# Altium schematic sheet Teensy.SchDoc(Teensy)
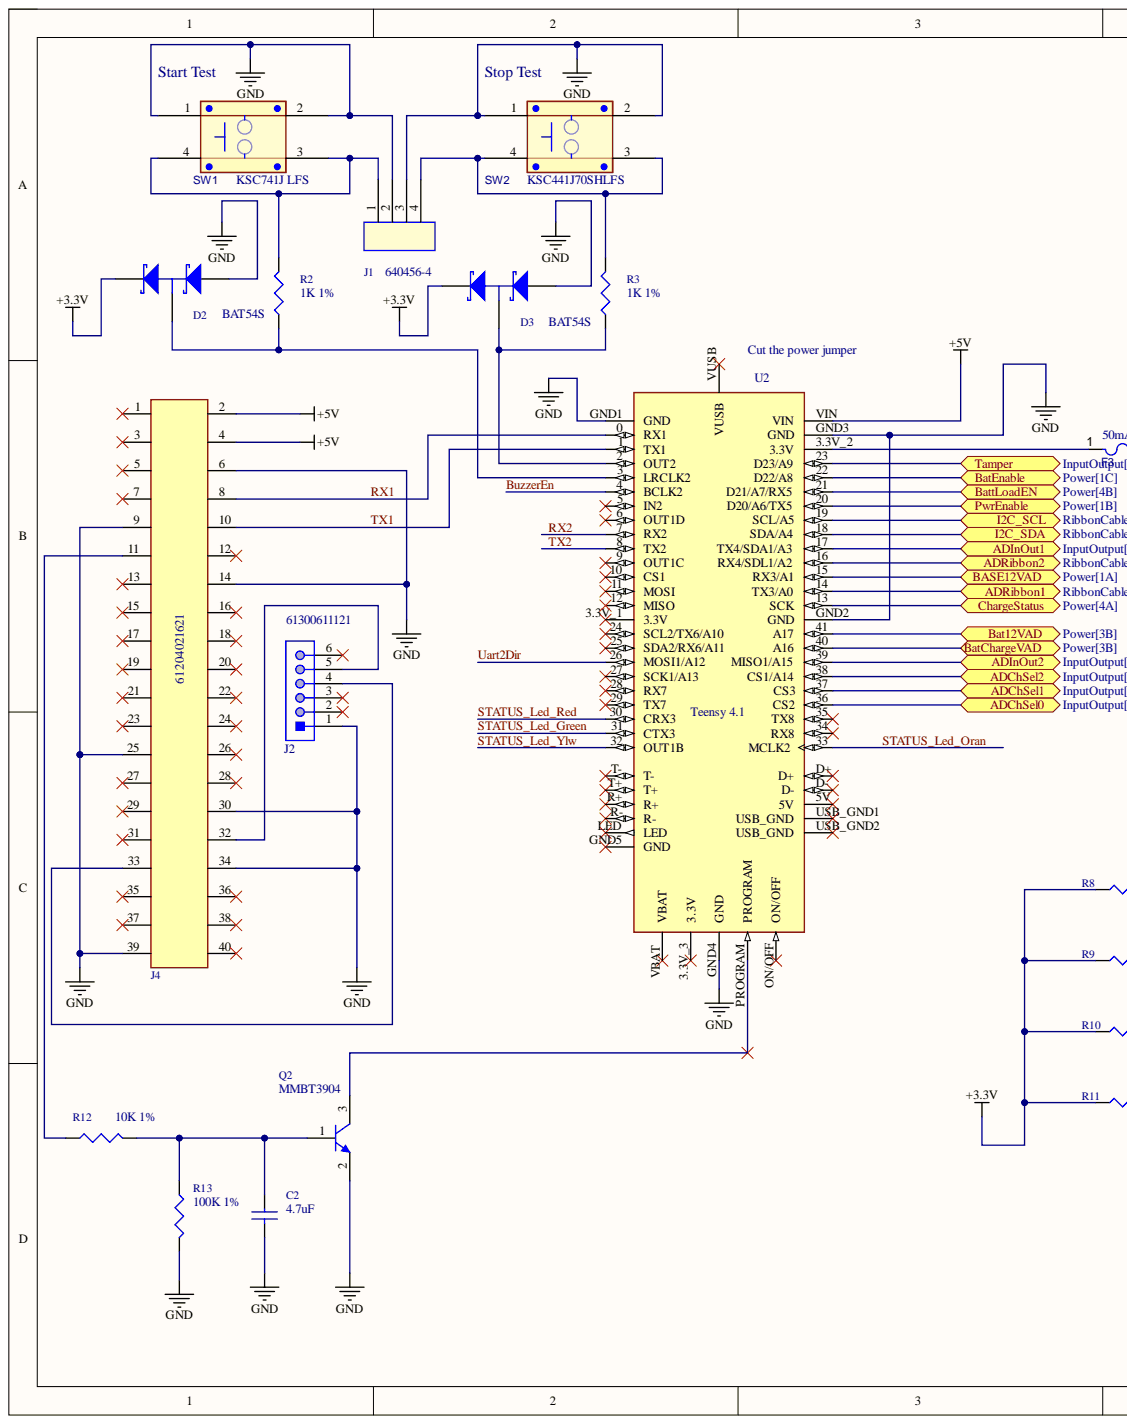
[diagram p0: Teensy.SchDoc, left]
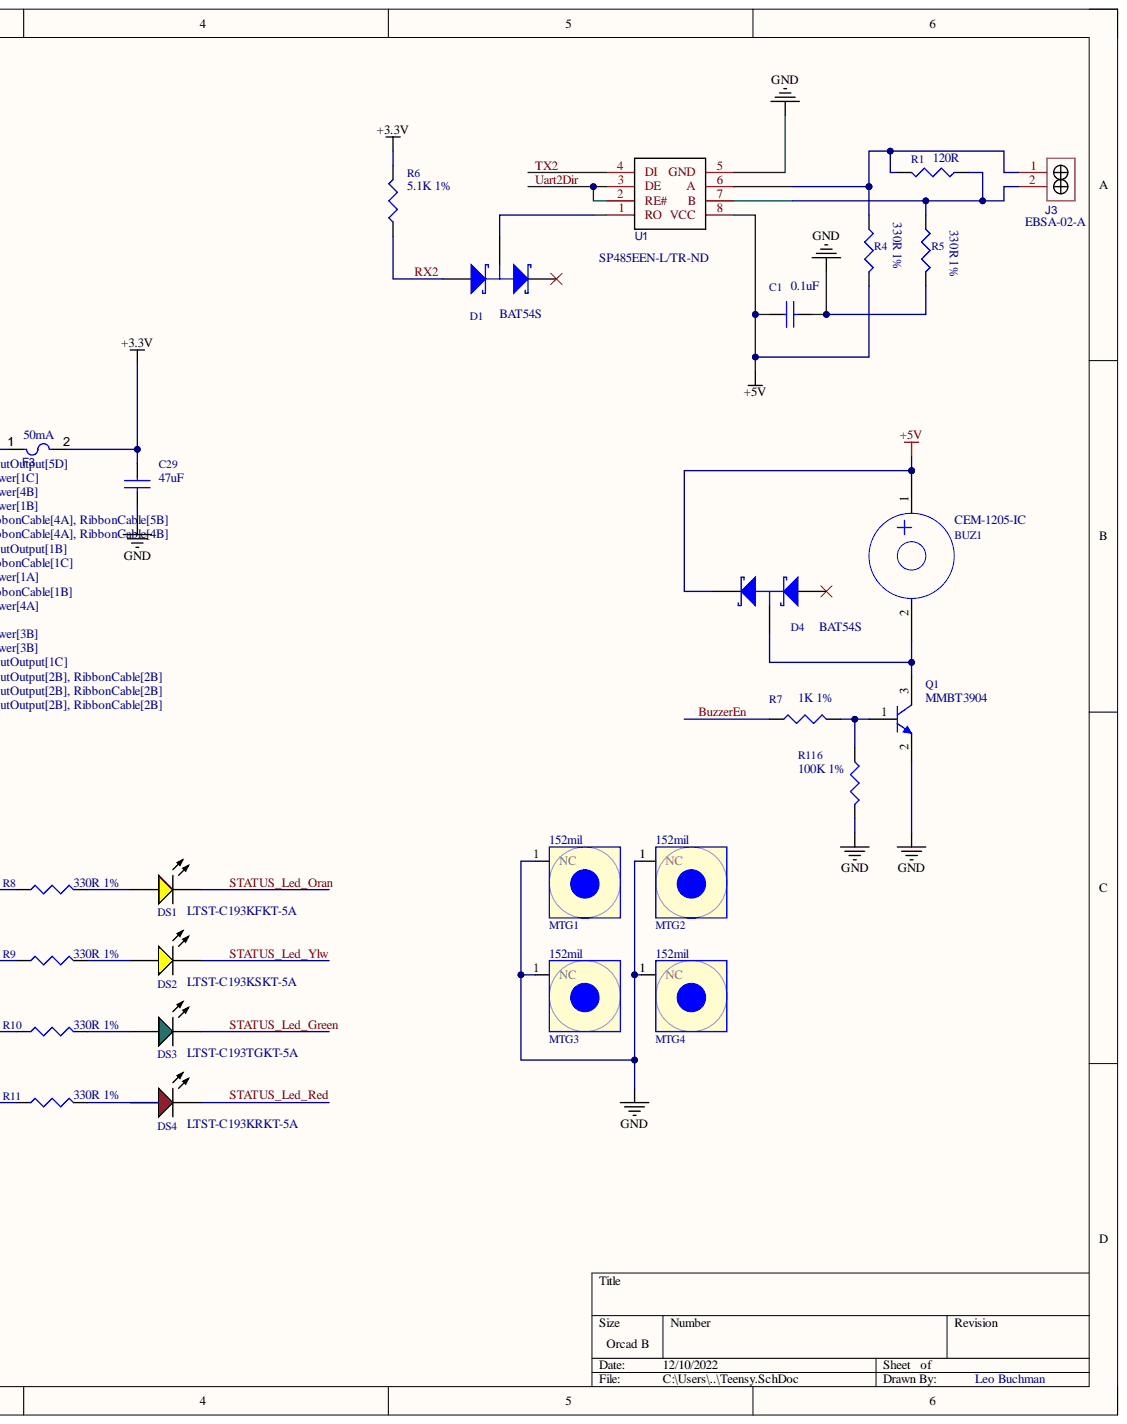
[diagram p1: Teensy.SchDoc, right]

## Parts
BUZ1  CEM-1205-IC  [BUZZ-MB5120C]
C1  0.1uF  [C0402]
C2  4.7uF  [C0603]
C29  47uF  [C1210]
D1  BAT54S  [sot23-3]
D2  BAT54S  [sot23-3]
D3  BAT54S  [sot23-3]
D4  BAT54S  [sot23-3]
DS1  LTST-C193KFKT-5A  [LED-ORANGE_0603]
DS2  LTST-C193KSKT-5A  [LED-YELLOW_0603]
DS3  LTST-C193TGKT-5A  [LED-GREEN_0603]
DS4  LTST-C193KRKT-5A  [LED-RED_0603]
F3  50mA  [F0603]
J1  640456-4  [CON$5C$4P$5C$100L]
J2  61300611121  [61300611121]
J3  EBSA-02-A  [CONN-TH_2P-P3.50_JL15EDGRC-35002G01]
J4  61204021621  [IDC-TH_Z-231011040106]
MTG1  152mil  [MNT-HOLE-169-350]  (no BOM)
MTG2  152mil  [MNT-HOLE-169-350]  (no BOM)
MTG3  152mil  [MNT-HOLE-169-350]  (no BOM)
MTG4  152mil  [MNT-HOLE-169-350]  (no BOM)
Q1  MMBT3904  [sot23-3]
Q2  MMBT3904  [sot23-3]
R1  120R  [R0402]
R2  1K 1%  [R0402]
R3  1K 1%  [R0402]
R4  330R 1%  Resistance: 330R  Tolerance: 1%  [R0402]
R5  330R 1%  Resistance: 330R  Tolerance: 1%  [R0402]
R6  5.1K 1%  [R0402]
R7  1K 1%  Tolerance: 1%  [R0402]
R8  330R 1%  Resistance: 330R  [R0402]
R9  330R 1%  Resistance: 330R  [R0402]
R10  330R 1%  Resistance: 330R  [R0402]
R11  330R 1%  Resistance: 330R  [R0402]
R12  10K 1%  Tolerance: 1%  [R0402]
R13  100K 1%  [R0402]
R116  100K 1%  [R0402]
SW1  KSC741J LFS  [SON4_KSC741JLFS_CNK-blue]
SW2  KSC441J70SHLFS  [SON4_KSC741JLFS_CNK-red]
U1  SP485EEN-L/TR-ND  [NSOIC-8]
U2  Teensy 4.1  [MODULE_DEV-16771 - headers]

## Ports
ADChSel0, ADChSel1, ADChSel2, ADInOut1, ADInOut2, ADRibbon1, ADRibbon2, BASE12VAD, Bat12VAD, BatChargeVAD, BatEnable, BattLoadEN, ChargeStatus, I2C_SCL, I2C_SDA, PwrEnable, Tamper

## Nets
+3.3V: C29.1 D2.2 D3.2 F3.2 R6.2 R8.1 R9.1 R10.1 R11.1
+5V: BUZ1.1 C1.1 D4.2 J4.2 J4.4 R4.2 U1.8(VCC) U2.VIN
GND: C1.2 C2.2 C29.2 D2.1 D3.1 J1.2 J1.3 J2.1 J4.6 J4.9 J4.14 J4.25 J4.30 J4.34 J4.39 MTG1.1 MTG2.1 MTG3.1 MTG4.1 Q1.2 Q2.2 R5.2 R13.2 R116.2 SW1.1 SW1.2 SW2.1 SW2.2 U1.5(GND) U2.GND1(GND) U2.GND2(GND) U2.GND3(GND) U2.GND4(GND)
ADChSel0: U2.36(CS2) ; port ADChSel0
ADChSel1: U2.37(CS3) ; port ADChSel1
ADChSel2: U2.38(CS1/A14) ; port ADChSel2
ADInOut1: U2.17(TX4/SDA1/A3) ; port ADInOut1
ADInOut2: U2.39(MISO1/A15) ; port ADInOut2
ADRibbon1: U2.14(TX3/A0) ; port ADRibbon1
ADRibbon2: U2.16(RX4/SDL1/A2) ; port ADRibbon2
BASE12VAD: U2.15(RX3/A1) ; port BASE12VAD
Bat12VAD: U2.41(A17) ; port Bat12VAD
BatChargeVAD: U2.40(A16) ; port BatChargeVAD
BatEnable: U2.22(D22/A8) ; port BatEnable
BattLoadEN: U2.21(D21/A7/RX5) ; port BattLoadEN
BuzzerEn: R7.1 U2.4(BCLK2)
ChargeStatus: U2.13(SCK) ; port ChargeStatus
I2C_SCL: U2.19(SCL/A5) ; port I2C_SCL
I2C_SDA: U2.18(SDA/A4) ; port I2C_SDA
PwrEnable: U2.20(D20/A6/TX5) ; port PwrEnable
RX1: J4.8 U2.0(RX1)
RX2: D1.1 R6.1 U2.7(RX2)
STATUS_Led_Green: DS3.2 U2.31(CTX3)
STATUS_Led_Oran: DS1.2 U2.33(MCLK2)
STATUS_Led_Red: DS4.2 U2.30(CRX3)
STATUS_Led_Ylw: DS2.2 U2.32(OUT1B)
Tamper: U2.23(D23/A9) ; port Tamper
TX1: J4.10 U2.1(TX1)
TX2: U1.4(DI) U2.8(TX2)
Uart2Dir: U1.2(RE#) U1.3(DE) U2.26(MOSI1/A12)
NetBUZ1_2: BUZ1.2 D4.3 Q1.3
NetC2_1: C2.1 Q2.1 R12.2 R13.1
NetD1_3: D1.3 U1.1(RO)
NetD2_3: D2.3 R2.1 U2.3(LRCLK2)
NetD3_3: D3.3 R3.1 U2.2(OUT2)
NetDS1_1: DS1.1 R8.2
NetDS2_1: DS2.1 R9.2
NetDS3_1: DS3.1 R10.2
NetDS4_1: DS4.1 R11.2
NetF3_1: F3.1 U2.3.3V_2(3.3V)
NetJ1_1: J1.1 R2.2 SW1.3 SW1.4
NetJ1_4: J1.4 R3.2 SW2.3 SW2.4
NetJ2_4: J2.4 J4.33
NetJ2_5: J2.5 J4.32
NetJ3_1: J3.1 R1.2 R4.1 U1.6(A)
NetJ3_2: J3.2 R1.1 R5.1 U1.7(B)
NetJ4_11: J4.11 R12.1
NetQ1_1: Q1.1 R7.2 R116.1
NetQ2_3: Q2.3 U2.PROGRAM

Not connected: D1 2; D4 1; J2 2 3 6; J4 1 3 5 7 12 13 15 16 17 18 19 20 21 22 23 24 26 27 28 29 31 35 36 37 38 40; U2 3.3V_1(3.3V) 3.3V_3(3.3V) 5(IN2) 5V 6(OUT1D) 9(OUT1C) 10(CS1) 11(MOSI) 12(MISO) 24(SCL2/TX6/A10) 25(SDA2/RX6/A11) 27(SCK1/A13) 28(RX7) 29(TX7) 34(RX8) 35(TX8) D+ D- GND5(GND) LED ON/OFF R+ R- T+ T- USB_GND1(USB_GND) USB_GND2(USB_GND) VBAT VUSB

## Library data of the parts
BUZ1 = Mod-0003: 12 mm, 5 Vdc, 92 dB, Through Hole, Driving Circuit, Magnetic Audio Indicator Buzzer; ADI Part Number: 3716-0001; Manufacturer: CUI Devices; CLASS: DISCRETE; COMPFIXED: NO; COMPGROUP: 0; COMPHEIGHT: 0.000; COMPLOC: [1301.000,1659.000]; COMPROT: 180; COMPSIDE: TOP; Current: 35mA; Database Table Name: Modules; S2 PN: S2-BZ-0001; Voltage: 5V; Category: Buzzers; COMPKEY: NO; COMPLOCKED: NO; Component Kind: Standard; DECOUPLER: NO; JLCPCB_PART: NA; LCSC Part Number: N/A; Manufacturer Part Number: CEM-1205-IC; Mount: Through Hole; Part Name: Mod-0003; PCB Footprint: BUZZ-MB5120C; Pricing 1: 1=1.87000, 10=1.55000, 50=1.35000, 100=1.2700; RoHS: Yes; S2PN: S2-BZ-0001; Stock 1: 774; Supplier 1: Mouser; Supplier Part Number 1: 490-CEM1205IC; Library Name: Leo-Home-SQL-Lib.DbLib
C1 = Cap-00005: Cap Ceramic 0.1uF 50V X7R 10% Pad SMD 0402 125C Automotive T/R; Manufacturer: Murata; Manufacturer Part Number: GRT155R71H104KE01D; Database Table Name: Capacitors; Case Code (Imperial): 0402; Case Code (Metric): 1005; Case/Package: 0402; Category: Ceramic Capacitors; Component Kind: Standard; Dielectric Material: Ceramic; LCSC Part Number: C307331; Mount: Surface Mount; Number of Pins: 2; Part Name: Cap-00005; Pricing 1: 1=0.10000, 10=0.04700, 50=0.02580, 100=0.0211; RoHS: Yes; RoHS Compliant: Yes; Stock 1: 179948; Supplier 1: Digi-Key; Supplier Part Number 1: 490-GRT155R71H104KE01DCT-ND; Voltage Rating (DC): 50V; Library Name: Leo-Home-SQL-Lib.DbLib
C2 = Cap-00001: CAP CER 4.7UF 16V X5R 0603; Manufacturer: Samsung; Manufacturer Part Number: CL10A475KO8NNNC; Database Table Name: Capacitors; Dielectric Material: Ceramic; Tolerance: 10%; Capacitance: 4.7uF; Case Code (Imperial): 0603; Case Code (Metric): 1608; Case/Package: 0603; Category: Ceramic Capacitors; Component Kind: Standard; ComponentLink1Description: https://datasheet.ciiva.com/25795/cl10a475ko8; ComponentLink1URL: https://datasheet.ciiva.com/25795/cl10a475ko8; ComponentLink2Description: https://datasheet.ciiva.com/26838/getdatashee; ComponentLink2URL: https://datasheet.ciiva.com/26838/getdatashee; ComponentLink3Description: https://datasheet.ciiva.com/29198/457663-da-0; ComponentLink3URL: https://datasheet.ciiva.com/29198/457663-da-0; Construction: Flat; Contact Plating: Tin; Depth: 0.8mm; Dielectric: X5R; Height: 0.8mm; LCSC Part Number: C19666; Length: 1.6mm; Material: Ceramic; Max Operating Temperature: 85°C; Min Operating Temperature: -55°C; Mount: Surface Mount; Number of Pins: 2; Package Quantity: 1; Packaging: Tape and Reel; Part Name: Cap-00001; Pricing 1: 1=0.13000, 10=0.09100, 50=0.05180, 100=0.0429; Radiation Hardening: No; RoHS: Yes; RoHS Compliant: Yes; Series: CL; Stock 1: 1593998; Supplier 1: Digi-Key; Supplier Part Number 1: 1276-1784-1-ND; Thickness: 0.035inch; Voltage: 16V; Voltage Rating: 16V; Width: 0.031inch; Library Name: Leo-Home-SQL-Lib.DbLib
C29 = Cap-00004: CAP CER 47UF 16V X5R 1210; Manufacturer: Murata; Manufacturer Part Number: GRM32ER61C476ME15L; Database Table Name: Capacitors; Dielectric Material: Ceramic; Tolerance: 20%; Capacitance: 47uF; Case Code (Imperial): 1210; Case Code (Metric): 3225; Case/Package: 1210; Category: Ceramic Capacitors; Component Kind: Standard; ComponentLink1Description: https://datasheet.ciiva.com/141/product-14197; ComponentLink1URL: https://datasheet.ciiva.com/141/product-14197; ComponentLink2Description: https://datasheet.ciiva.com/14116/grm32er61c4; ComponentLink2URL: https://datasheet.ciiva.com/14116/grm32er61c4; ComponentLink3Description: https://datasheet.ciiva.com/7719/415303-77191; ComponentLink3URL: https://datasheet.ciiva.com/7719/415303-77191; ComponentLink4Description: https://datasheet.ciiva.com/141/partnumbering; ComponentLink4URL: https://datasheet.ciiva.com/141/partnumbering; ComponentLink5Description: https://datasheet.ciiva.com/141/partnumbering; ComponentLink5URL: https://datasheet.ciiva.com/141/partnumbering; ComponentLink6Description: https://datasheet.ciiva.com/16343/c02e-163436; ComponentLink6URL: https://datasheet.ciiva.com/16343/c02e-163436; Depth: 2.5mm; Dielectric: X5R; Height: 2.5mm; LCSC Part Number: C77101; Lead Free: Lead Free; Length: 3.2mm; Max Operating Temperature: 85°C; Min Operating Temperature: -55°C; Mount: Surface Mount; Number of Pins: 2; Package Quantity: 1000; Packaging: Tape and Reel; Part Name: Cap-00004; Pricing 1: 1=0.73000, 10=0.53900, 50=0.43120, 100=0.3697; REACH SVHC: No SVHC; RoHS: Yes; RoHS Compliant: Yes; Series: GRM; Stock 1: 238447; Supplier 1: Digi-Key; Supplier Part Number 1: 490-3888-1-ND; Termination: SMD/SMT; Thickness: 0.106inch; Voltage: 16V; Voltage Rating: 16V; Voltage Rating (DC): 16V; Width: 0.098inch; Library Name: Leo-Home-SQL-Lib.DbLib
D1-D4 = Diode-0009: DIODE SCHOTTKY 30V 150MA SOT23-3; Manufacturer: Sangdest Microelectronics; Database Table Name: Diodes; Elements: 2; Package Version: Rev. 12, 03/2016; Peak Reverse Current: 2uA; Recovery Time: 5ns; Component Kind: Standard; Component Type: Diodes; Datasheet URL: https://www.fairchildsemi.com/datasheets/BA/BAT54S.pdf; Datasheet Version: Rev. 2.3, 04/2016; LCSC Part Number: C47546; Lead Free: Lead Free; Manufacturer Part Number: BAT54S; Manufacturer URL: https://www.fairchildsemi.com/; Mount: Surface Mount; Mounting Technology: SM; Package Description: 3-Pin Small Outline Transistor, Body 2.92 x 1.325 mm, Pitch 0.95 mm; Package Reference: SOT23-3; Package URL: http://www.fairchildsemi.com/dwg/MA/MA03D.pdf; Part Name: Diode-0009; Pins: 3; Pricing 1: 1=0.43000, 10=0.35100, 100=0.18620, 500=0.122; Radiation Hardening: No; RoHS: Yes; Stock 1: 168252; Supplier 1: Digi-Key; Supplier Part Number 1: 1655-BAT54SCT-ND; Library Name: Leo-Home-SQL-Lib.DbLib
DS1 = Diode-0004: LED Orange CLEAR 0603 SMD; Manufacturer: Vishay Lite-On; Database Table Name: Diodes; Dominant Wavelength: 605nm; Forward (Drive) Current: 20mA; Forward Current: 5mA; Forward Voltage: 2.0V; Intensity: 22mcd; Lens Color: Colorless; Lens Style: Diffused,Colorless,Rectangular,Clear; Luminous Intensity: 28.1mcd; Number of Elements: 1; Viewing Angle: 130°; Case Code (Imperial): 0603; Case Code (Metric): 1608; Case/Package: 0603; Category: LEDs; Color: Orange; Component Kind: Standard; Datasheet URL: https://datasheet.ciiva.com/11773/0900766b80df3ad8-11773825.pdf; Datasheet Version: Rev. 2.0, 03/2010; Dimension: 1.6x0.8x0.36mm; Height: 0.35mm; Illumination Color: Orange; LCSC Part Number: C434425; Lead Free: Lead Free; Lens Transparency: Clear; Lens Type: Clear; Manufacturer Part Number: LTST-C193KFKT-5A; Manufacturer URL: http://www.rohm.com/web/global/; Max Operating Temperature: 85°C; Min Operating Temperature: -55°C; Mount: Surface Mount; Mounting Technology: SurfaceMount; Number of Pins: 2; Package Description: 2-Pin Surface Mount Device, Body 1.6 x 0.8 mm; Package Reference: 1608; Packaging: Tape and Reel; Part Name: Diode-0004; Pricing 1: 1=0.39000, 10=0.20900, 100=0.09720, 500=0.089; Radiation Hardening: No; RoHS: Yes; RoHS Compliant: Yes; Stock 1: 55639; Supplier 1: Digi-Key; Supplier Part Number 1: 160-1829-1-ND; Width: 0.8mm; Library Name: Leo-Home-SQL-Lib.DbLib
DS2 = Diode-0006: LED Yellow CLEAR 0603 SMD; Manufacturer: Vishay Lite-On; Case\Package: 0603; Database Table Name: Diodes; Dominant Wavelength: 594.5nm; Forward Current: 30mA; Forward Voltage: 2.0V; Lens Color: Colorless; Lens Style: Diffused,Colorless,Rectangular; Luminous Intensity: 45mcd; Number of Elements: 1; Power Dissipation: 75mW; Resistance: 390 Ohm; Reverse Voltage: 5V; Test Current: 5mA; Viewing Angle: 130°; Voltage Rating: 50 V; Case Code (Imperial): 0603; Case Code (Metric): 1608; Case/Package: 0603; Category: LEDs; Color: Yellow; Component Kind: Standard; ComponentLink1Description: https://datasheet.ciiva.com/11775/0900766b80d; ComponentLink1URL: https://datasheet.ciiva.com/11775/0900766b80d; ComponentLink2Description: https://datasheet.ciiva.com/4688/lite-on-ltst; ComponentLink2URL: https://datasheet.ciiva.com/4688/lite-on-ltst; ComponentLink3Description: https://datasheet.ciiva.com/26890/getdatashee; ComponentLink3URL: https://datasheet.ciiva.com/26890/getdatashee; ComponentLink4Description: https://datasheet.ciiva.com/2110/s-110-ltst-c; ComponentLink4URL: https://datasheet.ciiva.com/2110/s-110-ltst-c; ComponentLink5Description: https://datasheet.ciiva.com/2110/s-110-ltst-c; ComponentLink5URL: https://datasheet.ciiva.com/2110/s-110-ltst-c; ComponentLink6Description: https://datasheet.ciiva.com/2110/s-110-ltst-c; ComponentLink6URL: https://datasheet.ciiva.com/2110/s-110-ltst-c; ComponentLink7Description: https://datasheet.ciiva.com/2110/ltst-c193kfk; ComponentLink7URL: https://datasheet.ciiva.com/2110/ltst-c193kfk; DatasheetVersion: Mar-12; Depth: 0.8mm; Height: 0.35mm; Illumination Color: Yellow; LCSC Part Number: C72038; Lead Free: Lead Free; Length: 1.6mm; Lens Transparency: Clear; Manufacturer Part Number: LTST-C193KSKT-5A; Max Operating Temperature: 85°C; Min Operating Temperature: -55°C; Mount: Surface Mount; Number of LEDs: 1; Number of Pins: 2; Package Quantity: 1; PackageDescription: 2-Pin Surface Mount Device, Body 1.6 x 0.8 mm, Height 0.9 mm; PackageReference: HSMX-C190; Packaging: Tape and Reel; Part Name: Diode-0006; Pins: 2; Power Rating: 75mW; Pricing 1: 1=0.39000, 10=0.20900, 100=0.09720, 500=0.089; Radiation Hardening: No; RoHS: Yes; RoHS Compliant: Yes; RoHSCompliant: true; Stock 1: 147319; Supplier 1: Digi-Key; Supplier Part Number 1: 160-1831-1-ND; Width: 0.8mm; Library Name: Leo-Home-SQL-Lib.DbLib
DS3 = Diode-0007: LED Green CLEAR 0603 SMD; Manufacturer: Vishay Lite-On; Access Time: 3500 ns; Average Rectified Current: 2A; Case\Package: SOIC; Clock Frequency: 100 KHz; Database Table Name: Diodes; Density: 32 kb; Dominant Wavelength: 535nm; Element Configuration: Single; Forward Current: 5mA; Forward Voltage: 2.0V; Frequency: 400 KHz; Lens Color: Colorless; Lens Style: Diffused,Colorless,Rectangular; Luminous Intensity: 280mcd; Max Repetitive Reverse Voltage (Vrrm): 40V; Max Reverse Current: 500uA; Max Supply Voltage: 5.5 V; Min Supply Voltage: 1.7 V; Nominal Supply Current: 2 mA; Number of Elements: 1; Peak Non-Repetitive Surge Current: 50A; Power Dissipation: 76mW; Reverse Voltage: 5V; Viewing Angle: 130°; Case Code (Imperial): 0603; Case Code (Metric): 1608; Case/Package: 0603; Category: LEDs; Color: Green; Component Kind: Standard; ComponentLink1Description: https://datasheet.ciiva.com/2111/ltst-c193tgk; ComponentLink1URL: https://datasheet.ciiva.com/2111/ltst-c193tgk; ComponentLink2Description: https://datasheet.ciiva.com/11775/0900766b80d; ComponentLink2URL: https://datasheet.ciiva.com/11775/0900766b80d; ComponentLink3Description: https://datasheet.ciiva.com/4689/lite-on-ltst; ComponentLink3URL: https://datasheet.ciiva.com/4689/lite-on-ltst; ComponentLink4Description: https://datasheet.ciiva.com/2111/p-100-ltst-c; ComponentLink4URL: https://datasheet.ciiva.com/2111/p-100-ltst-c; ComponentLink5Description: https://datasheet.ciiva.com/2111/p-100-ltst-c; ComponentLink5URL: https://datasheet.ciiva.com/2111/p-100-ltst-c; ComponentLink6Description: http://www.mouser.com/ds/2/115/B220AQ_Q-B260A; ComponentLink6URL: http://www.mouser.com/ds/2/115/B220AQ_Q-B260A; ComponentLink7Description: https://www.diodes.com/assets/Datasheets/ds13; ComponentLink7URL: https://www.diodes.com/assets/Datasheets/ds13; ComponentLink8Description: http://www.diodes.com/datasheets/ds13004.pdf?; ComponentLink8URL: http://www.diodes.com/datasheets/ds13004.pdf?; Contact Plating: Tin,Matte; DatasheetVersion: Mar-12; Depth: 0.8mm; Height: 0.35mm; Illumination Color: Green; LCSC Part Number: C72043; Lead Free: Lead Free; Length: 1.6mm; Lens Transparency: Clear; Manufacturer Part Number: LTST-C193TGKT-5A; Max Clock Frequency: 100 KHz; Max Operating Temperature: 80°C; Max Reverse Voltage (DC): 40V; Min Operating Temperature: -20°C; Mount: Surface Mount; Number of LEDs: 1; Number of Pins: 2; Package Quantity: 3000; PackageDescription: 2-Pin Surface Mount Device, Body 1.6 x 0.8 mm, Height 0.9 mm; PackageReference: HSMX-C190; Packaging: Tape and Reel; Part Name: Diode-0007; Pins: 8; Pricing 1: 1=0.45000, 10=0.27400, 100=0.15780, 1000=0.12; Radiation Hardening: No; RoHS: Yes; RoHS Compliant: Yes; RoHSCompliant: true; Series: -; Stock 1: 429188; Supplier 1: Digi-Key; Supplier Part Number 1: 160-1832-1-ND; Test Current: 5mA; Width: 0.8mm; Library Name: Leo-Home-SQL-Lib.DbLib
DS4 = Diode-0005: LED RED CLEAR 0603 SMD; Manufacturer: Vishay Lite-On; Chip Material: GaAlAs; Database Table Name: Diodes; DELETED: Compliant; Dominant Wave Length: 639nm; Dominant Wavelength: 631nm; Forward Current: 5mA; Forward Voltage: 2.0V; High Brightness: No; Lens Color: Colorless; Lens Style: Diffused,Colorless,Clear,Rectangular; Lens Type: ColorlessDiffused; Lum Intensity: 17mcd; Luminous Intensity: 45mcd; Main Category: ChipLED; Number of  Elements: 1; Peak Wavelength: 660nm; Power Dissipation: 50mW; Product Depth (mm): 1.6mm; Product Height (mm): 1.1mm; Product Length (mm): 3.2mm; Reverse Voltage: 5V; Shape Type: Rectangular; Test Current (It): 20mA; Type: Uni-Color; Viewing Angle: 130°; Case Code (Imperial): 0603; Case Code (Metric): 1608; Case/Package: 0603; Category: LEDs; Color: Red; Component Kind: Standard; ComponentLink1Description: https://datasheet.ciiva.com/11775/0900766b80d; ComponentLink1URL: https://datasheet.ciiva.com/11775/0900766b80d; ComponentLink2Description: https://datasheet.ciiva.com/4689/100-ltst-c19; ComponentLink2URL: https://datasheet.ciiva.com/4689/100-ltst-c19; ComponentLink3Description: https://datasheet.ciiva.com/16210/ltst-c193kr; ComponentLink3URL: https://datasheet.ciiva.com/16210/ltst-c193kr; ComponentLink4Description: https://datasheet.ciiva.com/2110/s-110-ltst-c; ComponentLink4URL: https://datasheet.ciiva.com/2110/s-110-ltst-c; Datasheet URL: http://media.digikey.com/pdf/Data%20Sheets/Avago%20PDFs/HSMz-Czzz.pdf; Datasheet Version: 03/2012; Depth: 0.8mm; Emitting Color: Red; Height: 0.35mm; Illumination Color: Red; LCSC Part Number: C2286; Lead Free: Lead Free; Length: 1.6mm; Lens Dimensions: 2x1.6x0.6mm; Lens Transparency: Clear; Manufacturer Part Number: LTST-C193KRKT-5A; Manufacturer URL: http://www.avagotech.com/; Max Operating Temperature: 85°C; Min Operating Temperature: -55°C; Mount: Surface Mount; Mounting: SurfaceMount; Mounting Technology: SurfaceMount; Number of Elements: 1; Number of LEDs: 1; Number of Pins: 2; Operating Temp Range: -40Cto85C; Operating Temperature Classification: Industrial; Package Description: 2-Pin Surface Mount Device, Body 3.2 x 1.6 mm; Package Quantity: 3000; Package Reference: HSMX-C150; Package Type: ChipLed; Packaging: Tape and Reel; Part Name: Diode-0005; Pin Count: 2; Pricing 1: 1=0.39000, 10=0.20900, 100=0.09720, 500=0.089; Rad Hardened: No; Radiation Hardening: No; RoHS: Yes; RoHS Compliant: Yes; Series: -; Stock 1: 476214; Supplier 1: Digi-Key; Supplier Part Number 1: 160-1830-1-ND; Test Current: 5mA; Width: 0.8mm; Library Name: Leo-Home-SQL-Lib.DbLib
F3 = FEMTOASMDC005F-2: Ptc Reset Fuse 15V 50MA 0603; Manufacturer: Littelfuse; Database Table Name: Fuses; Max Current Rating: 10A; Time to Trip: 100ms; Trip Current: 150mA; Case Code (Imperial): 0603; Case Code (Metric): 1608; Case/Package: 0603; Category: PTC Resettable Fuses; Component Kind: Standard; Component Type: Fuses; ComponentLink1Description: https://media.digikey.com/pdf/Data%20Sheets/L; ComponentLink1URL: https://media.digikey.com/pdf/Data%20Sheets/L; Datasheet URL: http://www.bourns.com/data/global/pdfs/mfmsmf.pdf; Datasheet Version: Rev. AG, 08/2016; Hold Current: 50mA; LCSC Part Number: C2832197; Manufacturer Part Number: FEMTOASMDC005F-2; Mount: Surface Mount; Mounting Technology: SM; Package Description: 2-Pin Surface Mount Device, Body 4.55 x 3.24 mm; Package Reference: MF-MSMF050-2; Packaging: Cut Tape; Part Name: FEMTOASMDC005F-2; Pricing 1: 1=0.90000, 5=0.87600, 10=0.82000, 50=0.67820,; RoHS: Yes; Stock 1: 11749; Supplier 1: Digi-Key; Supplier Part Number 1: F9952CT-ND; Library Name: Leo-Home-SQL-Lib.DbLib
J1 = CON-0012: Header, Friction Lock; MTA-100; 4; 250 VAC; P; Manufacturer: TE Connectivity; Approvals: CSA; Backwall/Post Interruptions: No (Without); Circuit Application: Signal; Connector System: Wire-to-Board; Connector Type: Connector,Pin,Plug,Header; Contact Current Rating: 5A; Contact Post Length: 3.56mm; Contact Resistance: 6mR; Contact Style: Straight; Database Table Name: Connectors; Decription: CONN HEADER VERT 4POS; Gender: Male; Mating Connector Lock: Yes (With); Max Voltage Rating (AC): 250V; Number of Contacts: 4; PCB Footprint: CON\4P\100L; PCB Mount Retention: Without; PCB Mounting Orientation: Vertical; PCB Thickness: 0.063inch; Pitch: 2.54mm; Plating Thickness: 3.81um; Tail Length: 0.14inch; Underplate Material: Nickel; Category: Connectors; Color: Natural,Beige,White; Component Kind: Standard; ComponentLink1Description: https://datasheet.ciiva.com/36859/38054-36859; ComponentLink1URL: https://datasheet.ciiva.com/36859/38054-36859; ComponentLink2Description: https://datasheet.ciiva.com/16286/eng-ds-8205; ComponentLink2URL: https://datasheet.ciiva.com/16286/eng-ds-8205; ComponentLink3Description: https://datasheet.ciiva.com/11746/0900766b800; ComponentLink3URL: https://datasheet.ciiva.com/11746/0900766b800; ComponentLink4Description: https://datasheet.ciiva.com/25618/0900766b80e; ComponentLink4URL: https://datasheet.ciiva.com/25618/0900766b80e; ComponentLink5Description: https://datasheet.ciiva.com/19462/613958-1946; ComponentLink5URL: https://datasheet.ciiva.com/19462/613958-1946; ComponentLink6Description: https://datasheet.ciiva.com/709/eng-cd-640456; ComponentLink6URL: https://datasheet.ciiva.com/709/eng-cd-640456; ComponentLink7Description: https://datasheet.ciiva.com/14637/70083644-14; ComponentLink7URL: https://datasheet.ciiva.com/14637/70083644-14; ComponentLink8Description: https://datasheet.ciiva.com/14156/mta-cst-100; ComponentLink8URL: https://datasheet.ciiva.com/14156/mta-cst-100; ComponentLink9Description: https://datasheet.ciiva.com/16656/eng-ds-8205; ComponentLink9URL: https://datasheet.ciiva.com/16656/eng-ds-8205; ComponentLink10Description: https://datasheet.ciiva.com/11746/0900766b806; ComponentLink10URL: https://datasheet.ciiva.com/11746/0900766b806; ComponentLink11Description: https://datasheet.ciiva.com/15175/getdatashee; ComponentLink11URL: https://datasheet.ciiva.com/15175/getdatashee; ComponentLink12Description: https://datasheet.ciiva.com/16394/eng-ds-8205; ComponentLink12URL: https://datasheet.ciiva.com/16394/eng-ds-8205; ComponentLink13Description: https://datasheet.ciiva.com/6045/1650873-6045; ComponentLink13URL: https://datasheet.ciiva.com/6045/1650873-6045; ComponentLink14Description: https://datasheet.ciiva.com/709/eng-cd-640454; ComponentLink14URL: https://datasheet.ciiva.com/709/eng-cd-640454; ComponentLink15Description: https://datasheet.ciiva.com/7703/eng-ds-82056; ComponentLink15URL: https://datasheet.ciiva.com/7703/eng-ds-82056; ComponentLink16Description: https://datasheet.ciiva.com/3118/eng-ds-82056; ComponentLink16URL: https://datasheet.ciiva.com/3118/eng-ds-82056; Contact Gender: Male; Contact Material: Copper Alloy; Contact Mating Length: 7.49mm; Contact Plating: Tin; Contact Retention: No (Without); Contact Shape: Square; Contact Type: Male Pin; Current Rating: 5A; Depth: 5.72mm; ELV: Compliant; Fastening Type: Friction; Flammability Rating: UL94 V-0; Height: 7.87mm; Housing Color: Natural; Housing Material: Polyester; JLCPCB_PART: 640454-4; LCSC Part Number: C305804; Lead Free: Lead Free; Lead Pitch: 2.54mm; Length: 12.7mm; Manufacturer Part Number: 640456-4; Mating Alignment: No (Without); Mating Retention: No (Without); Max Operating Temperature: 221°C; Min Operating Temperature: -67°C; Mount: Through Hole; Mounting Ears: No (Without); Number of Poles: 4; Number of Positions: 4; Number of Rows: 1; Orientation: Straight; Package Quantity: 5000; Packaging: Bulk; Panel Mount Retention: No (Without); Part Name: CON-0012; PCB Mount Alignment: No (Without); Pricing 1: 1=0.28000, 10=0.23900, 100=0.18620, 500=0.159; Radiation Hardening: No; REACH SVHC: No SVHC; RoHS: Yes; RoHS Compliant: Yes; Sealable: No (Without); Series: MTA-100; Shrouded: No (Without); Stock 1: 220089; Strain Relief: No (Without); Supplier 1: Digi-Key; Supplier Part Number 1: A1922-ND; Termination: Solder; Voltage Rating: 250V; Voltage Rating (AC): 250V; Weight: 0.01411oz; Working Voltage: 250V; Library Name: Leo-Home-SQL-Lib.DbLib
J2 = CON-0003: CONN HEADER 6 POS 2.54; Manufacturer: Wurth Electronics; Database Table Name: Connectors; Category: Headers and Wire Housings; Color: Black; Component Kind: Standard; Contact Material: Copper Alloy,Copper; Contact Plating: Gold; Housing Material: PBT; LCSC Part Number: C2833331; Manufacturer Part Number: 61300611121; Max Operating Temperature: 125°C; Min Operating Temperature: -40°C; Mount: Through Hole; Number of Rows: 1; Part Name: CON-0003; Pricing 1: 1=0.35000, 10=0.29800, 25=0.24720, 50=0.20700; RoHS: Yes; Stock 1: 57047; Supplier 1: Digi-Key; Supplier Part Number 1: 732-5319-ND; Library Name: Leo-Home-SQL-Lib.DbLib
J3 = CON-0001: Conn Header Right angle 3.5 pitch 2P; Manufacturer: Adam Equipment; Connector Type: Male Pin; Database Table Name: Connectors; Number of Positions: 6; Pitch: 0.1inch; Category: Connectors; Color: Black; Component Kind: Standard; ComponentLink1Description: https://datasheet.ciiva.com/741/xrxczzzsxxn-r; ComponentLink1URL: https://datasheet.ciiva.com/741/xrxczzzsxxn-r; Contact Mating Length: 0.318inch; Contact Plating: Gold; Contact Type: Male Pin; LCSC Part Number: C409115; Manufacturer Part Number: EBSA-02-A; Mount: Through Hole; Number of Rows: 1; Package Quantity: 1; Packaging: Bulk; Part Name: CON-0001; Pricing 1: 1=0.58000, 10=0.31600, 25=0.23600, 50=0.21040; Pricing 2: 1=0.21000, 10=0.19800, 25=0.16920, 50=0.14820; RoHS: Yes; RoHS Compliant: Yes; Series: -; Stock 1: 2090; Stock 2: 1241; Supplier 1: Digi-Key; Supplier 2: Digi-Key; Supplier Part Number 1: 2057-EBSA-02-A-ND; Supplier Part Number 2: S1211EC-06-ND; Termination: Solder; Library Name: Leo-Home-SQL-Lib.DbLib
J4 = CON-0009: Male Box Header WR-BHD, THT, Vertical, pitch; Manufacturer: Wurth Electronics; Connector Type: Male Pin; Database Table Name: Connectors; Number of Contacts: 40; Pitch: 0.1inch; Category: Headers and Wire Housings; Color: Black; Component Kind: Standard; Contact Material: Copper; Contact Plating: Gold; Gender: Male; LCSC Part Number: C221057; Manufacturer Part Number: 61204021621; Mount: Through Hole; Number of Positions: 40; Number of Rows: 2; Packaging: Tray; Part Name: CON-0009; Pricing 1: 1=1.21000, 10=1.16000, 80=1.02000, 160=0.8800; RoHS: Yes; Stock 1: 3521; Supplier 1: Digi-Key; Supplier Part Number 1: 732-5401-ND; Termination: Solder; Library Name: Leo-Home-SQL-Lib.DbLib
MTG1-MTG4 = Hole-001: Plated; Database Table Name: Mounting-holes; Part Name: Hole-001; Library Name: Leo-Home-SQL-Lib.DbLib
Q1 Q2 = Tran-0002: Trans GP BJT NPN 60V 0.2A 350mW Automotive 3-Pin SOT-23 T/R; Manufacturer: ON Semiconductor / Fairchild; Collector-emitter Voltage-Max: 400mV; Database Table Name: Transistors; Elements: 1; Frequency: 250MHz; Max Collector Current: 200mA; Power: 225mW; Vce Max: 300mV; Case/Package: SOT-23-3; Component Kind: Standard; Component Type: Transistors; ComponentLink1Description: https://datasheet.ciiva.com/22664/1708270-226; ComponentLink1URL: https://datasheet.ciiva.com/22664/1708270-226; ComponentLink2Description: https://datasheet.ciiva.com/4721/mmbt3906lt1-; ComponentLink2URL: https://datasheet.ciiva.com/4721/mmbt3906lt1-; ComponentLink3Description: https://datasheet.ciiva.com/11201/mmbt3906lt1; ComponentLink3URL: https://datasheet.ciiva.com/11201/mmbt3906lt1; ComponentLink4Description: https://datasheet.ciiva.com/16387/mmbt3906lt1; ComponentLink4URL: https://datasheet.ciiva.com/16387/mmbt3906lt1; ComponentLink5Description: https://datasheet.ciiva.com/976/mmbt3906lt1-d; ComponentLink5URL: https://datasheet.ciiva.com/976/mmbt3906lt1-d; ComponentLink6Description: https://datasheet.ciiva.com/976/mmbt3906lt1-d; ComponentLink6URL: https://datasheet.ciiva.com/976/mmbt3906lt1-d; ComponentLink7Description: https://datasheet.ciiva.com/976/mmbt3906lt1-d; ComponentLink7URL: https://datasheet.ciiva.com/976/mmbt3906lt1-d; Datasheet URL: http://www.onsemi.cn/pub_link/Collateral/MMBT3904LT1-D.PDF; Datasheet Version: Rev. 12, 11/2012; Height: 0.94mm; LCSC Part Number: C20526; Lead Free: Lead Free; Length: 2.9mm; Manufacturer Part Number: MMBT3904; Max Frequency: 250MHz; Max Operating Temperature: 150°C; Min Operating Temperature: -55°C; Mount: Surface Mount; Mounting Technology: SM; Number of Elements: 1; Package Description: 3-Pin Small Outline Transistor, Body 2.9 x 1.3 mm, Pitch 0.95 mm; Package Reference: SOT-23 (318-08); Part Name: Tran-0002; Pins: 3; Polarity: NPN; Radiation Hardening: No; REACH SVHC: No SVHC; RoHS: Yes; RoHS Compliant: Yes; Series: -; Supplier 1: Newark; Supplier Part Number 1: 58K9431; Width: 1.3mm; Library Name: Leo-Home-SQL-Lib.DbLib
R1 = Res-00013: Res Thick Film 0402 120 Ohm 1% 0.063W(1/16W) ±100ppm/C Pad SMD Automotive T/R; Manufacturer: Stackpole Electronics; Manufacturer Part Number: RMCF0402FT120R; Database Table Name: Resistors; Max Power Dissipation: 63mW; Temperature Coefficient: 100ppm/°C; Case Code (Imperial): 0402; Case Code (Metric): 1005; Case/Package: 0402; Category: Chip SMD Resistors; Component Kind: Standard; ComponentLink1Description: http://components.arrow.com/part/dataview/514; ComponentLink1URL: http://components.arrow.com/part/dataview/514; ComponentLink2Description: https://www.seielect.com/catalog/SEI-RMCF_RMC; ComponentLink2URL: https://www.seielect.com/catalog/SEI-RMCF_RMC; ComponentLink3Description: http://www.seielect.com/Catalog/SEI-RMCF_RMCP; ComponentLink3URL: http://www.seielect.com/Catalog/SEI-RMCF_RMCP; ComponentLink4Description: https://www.seielect.com/Catalog/SEI-RMCF_RMC; ComponentLink4URL: https://www.seielect.com/Catalog/SEI-RMCF_RMC; ComponentLink5Description: https://www.seielect.com/catalog/SEI-Packagin; ComponentLink5URL: https://www.seielect.com/catalog/SEI-Packagin; Contact Plating: Tin,Matte; Features: Automotive AEC-Q200; Height: 0.016inch; LCSC Part Number: C25079; Length: 0.039inch; Max Operating Temperature: 155°C; Min Operating Temperature: -55°C; Mount: Surface Mount; Number of Terminations: 2; Package Quantity: 10000; Packaging: Tape and Reel; Part Name: Res-00013; Power Rating: 63mW; Pricing 1: 1=0.10000, 10=0.01500, 100=0.00610, 1000=0.00; Radiation Hardening: No; Resistance: 120R; Resistor Type: Thick Film; RoHS: Yes; RoHS Compliant: Yes; Series: RMCF; Stock 1: 195943; Supplier 1: Digi-Key; Supplier Part Number 1: RMCF0402FT120RCT-ND; Tolerance: 1%; Width: 0.02inch; Library Name: Leo-Home-SQL-Lib.DbLib
R2 R3 R7 = Res-00001: Thick Film 0402 1K Ohm 1% 1/8W 0402; Manufacturer: TE Connectivity; Manufacturer Part Number: CRGP0402F1K0; Database Table Name: Resistors; Max Power Dissipation: 125mW; Power Rating: 0.125W; Temperature Coefficient: 100ppm/°C; Case Code (Imperial): 0402; Case Code (Metric): 1005; Case/Package: 0402; Category: Chip SMD Resistors; Component Kind: Standard; ComponentLink1Description: https://www.te.com/commerce/DocumentDelivery/; ComponentLink1URL: https://www.te.com/commerce/DocumentDelivery/; ComponentLink4Description: none; LCSC Part Number: C25792; Max Operating Temperature: 155°C; Min Operating Temperature: -55°C; Mount: Surface Mount; Number of Terminations: 2; Packaging: Tape and Reel; Part Name: Res-00001; Pricing 1: 1=0.12000, 10=0.11100, 100=0.04270, 1000=0.01; Resistance: 1k; Resistor Type: Thick Film; RoHS: Yes; RoHS Compliant: Yes; Stock 1: 16450; Supplier 1: Digi-Key; Supplier Part Number 1: A130355CT-ND; Library Name: Leo-Home-SQL-Lib.DbLib
  R2 R3: Tolerance: 1%
R4 R5 R8-R11 = Res-00009: Thick Film 0402 330 Ohm 1% 1/16W ±100ppm/°C Molded SMD Paper T/R; Manufacturer: Yageo; Manufacturer Part Number: AF0402FR-07330RL; Database Table Name: Resistors; Max Power Dissipation: 63mW; Power Rating: 62.5mW; Case Code (Imperial): 0402; Case Code (Metric): 1005; Case/Package: 0402; Category: Chip SMD Resistors; Component Kind: Standard; ComponentLink1Description: http://www.yageo.com/documents/recent/PYu-AF_; ComponentLink1URL: http://www.yageo.com/documents/recent/PYu-AF_; Features: Moisture Resistant,Anti-Sulfur; Height: 0.015inch; LCSC Part Number: C51721; Length: 0.039inch; Max Operating Temperature: 155°C; Min Operating Temperature: -55°C; Mount: Surface Mount; Number of Terminations: 2; Packaging: Tape and Reel; Part Name: Res-00009; Pricing 1: 1=0.10000, 10=0.05800, 100=0.02360, 1000=0.01; Radiation Hardening: No; Resistor Type: Thick Film; RoHS: Yes; RoHS Compliant: Yes; Stock 1: 15948; Supplier 1: Digi-Key; Supplier Part Number 1: 13-AF0402FR-07330RLCT-ND; Temperature Coefficient: 100ppm/°C; Width: 0.02inch; Library Name: Leo-Home-SQL-Lib.DbLib
  R8-R11: Tolerance: 1%
R6 = Res-00004: Thick Film 0402 5.1K Ohm 1% 1/16W 0402; Manufacturer: Stackpole Electronics; Manufacturer Part Number: RMCF0402FT5K10; Database Table Name: Resistors; Max Power Dissipation: 63mW; Power Rating: 62.5mW; Temperature Coefficient: 100ppm/°C; Case Code (Imperial): 0402; Case Code (Metric): 1005; Case/Package: 0402; Category: Chip SMD Resistors; Component Kind: Standard; ComponentLink1Description: http://components.arrow.com/part/dataview/513; ComponentLink1URL: http://components.arrow.com/part/dataview/513; ComponentLink2Description: https://www.seielect.com/catalog/SEI-RMCF_RMC; ComponentLink2URL: https://www.seielect.com/catalog/SEI-RMCF_RMC; ComponentLink3Description: https://www.seielect.com/catalog/SEI-Packagin; ComponentLink3URL: https://www.seielect.com/catalog/SEI-Packagin; ComponentLink4Description: https://datasheet.ciiva.com/15193/getdatashee; ComponentLink4URL: https://datasheet.ciiva.com/15193/getdatashee; Construction: Rectangular; Contact Plating: Tin,Matte; Depth: 0.5mm; Features: Automotive AEC-Q200; Height: 0.016inch; LCSC Part Number: C25905; Lead Free: Lead Free; Length: 0.039inch; Max Operating Temperature: 155°C; Military Standard: Not; Min Operating Temperature: -55°C; Mount: Surface Mount; Number of Terminations: 2; Packaging: Tape and Reel; Part Name: Res-00004; Pricing 1: 1=0.10000, 10=0.01500, 100=0.00610, 1000=0.00; Radiation Hardening: No; Resistance: 5.1k; Resistor Type: Thick Film; RoHS: Yes; RoHS Compliant: Yes; Series: RMCF; Size Code: 0402; Stock 1: 131490; Supplier 1: Digi-Key; Supplier Part Number 1: RMCF0402FT5K10CT-ND; Tolerance: 1%; Width: 0.02inch; Library Name: Leo-Home-SQL-Lib.DbLib
R12 = Res-00002: Thick Film 0402 10K OHM 1% 1/16W 0402; Manufacturer: Yageo; Manufacturer Part Number: RC0402FR-0710KP; Database Table Name: Resistors; Max Power Dissipation: 63mW; Power Rating: 62.5mW; Temperature Coefficient: 150ppm/°C; Case Code (Imperial): 0402; Case Code (Metric): 1005; Case/Package: 0402; Category: Chip SMD Resistors; Component Kind: Standard; ComponentLink1Description: http://www.yageo.com/NewPortal/yageodocoutput; ComponentLink1URL: http://www.yageo.com/NewPortal/yageodocoutput; Features: Moisture Resistant; Height: 0.016inch; LCSC Part Number: C25744; Length: 0.039inch; Max Operating Temperature: 155°C; Min Operating Temperature: -55°C; Mount: Surface Mount; Number of Terminations: 2; Packaging: Tape and Reel; Part Name: Res-00002; Pricing 1: 1=0.10000, 10=0.03600, 100=0.01450, 1000=0.00; Resistance: 10k; Resistor Type: Thick Film; RoHS: Yes; RoHS Compliant: Yes; Stock 1: 161500; Supplier 1: Digi-Key; Supplier Part Number 1: YAG1249CT-ND; Width: 0.02inch; Library Name: Leo-Home-SQL-Lib.DbLib
R13 R116 = Res-00003: Thick Film 0402 100K OHM 1% 1/16W 0402; Manufacturer: Stackpole Electronics; Manufacturer Part Number: RMCF0402FT100K; Database Table Name: Resistors; Power Rating: 62.5mW; Case Code (Imperial): 0402; Case Code (Metric): 1005; Case/Package: 0402; Category: Chip SMD Resistors; Component Kind: Standard; Contact Plating: Tin,Matte; LCSC Part Number: C25741; Mount: Surface Mount; Part Name: Res-00003; Pricing 1: 1=0.10000, 10=0.01500, 100=0.00610, 1000=0.00; RoHS: Yes; Stock 1: 13703190; Supplier 1: Digi-Key; Supplier Part Number 1: RMCF0402FT100KCT-ND; Library Name: Leo-Home-SQL-Lib.DbLib
SW1 = SW-0001: Switch Tactile N.O. SPST Round Button J-Bend; Manufacturer: ITT C&K; Actuator Color: Blue; Actuator Length: 1.7mm; Actuator Type: Standard; Current Rating: 50mA; Database Table Name: Switches; Illumination Type: Non-Illuminated; Max Voltage Rating (DC): 32V; Mechanical Life: 300000Cycles; Operating Force: 4N; Power Rating: 1W; Ratings: IP67,Waterproof,Dust Tight; Switch Function: Off-Mom; Switch Travel: 0.4mm; Case/Package: SMD/SMT; Category: Tactile Switches; Component Kind: Standard; ComponentLink1Description: https://datasheet.ciiva.com/16458/ksc741jlfs-; ComponentLink1URL: https://datasheet.ciiva.com/16458/ksc741jlfs-; ComponentLink2Description: https://datasheet.ciiva.com/2900/ksc-2900326.; ComponentLink2URL: https://datasheet.ciiva.com/2900/ksc-2900326.; ComponentLink3Description: https://datasheet.ciiva.com/2900/ksc-2900327.; ComponentLink3URL: https://datasheet.ciiva.com/2900/ksc-2900327.; ComponentLink4Description: https://datasheet.ciiva.com/2900/ksc-2900328.; ComponentLink4URL: https://datasheet.ciiva.com/2900/ksc-2900328.; ComponentLink5Description: https://datasheet.ciiva.com/22829/0900766b80a; ComponentLink5URL: https://datasheet.ciiva.com/22829/0900766b80a; ComponentLink6Description: https://datasheet.ciiva.com/16298/ksc441j70sh; ComponentLink6URL: https://datasheet.ciiva.com/16298/ksc441j70sh; ComponentLink7Description: https://datasheet.ciiva.com/18144/ksc-22jan15; ComponentLink7URL: https://datasheet.ciiva.com/18144/ksc-22jan15; ComponentLink8Description: https://datasheet.ciiva.com/2900/ksc-2900355.; ComponentLink8URL: https://datasheet.ciiva.com/2900/ksc-2900355.; ComponentLink9Description: https://datasheet.ciiva.com/2900/ksc-2900356.; ComponentLink9URL: https://datasheet.ciiva.com/2900/ksc-2900356.; ComponentLink10Description: https://datasheet.ciiva.com/2900/ksc-2900357.; ComponentLink10URL: https://datasheet.ciiva.com/2900/ksc-2900357.; ComponentLink11Description: https://datasheet.ciiva.com/16155/ksc-11mar10; ComponentLink11URL: https://datasheet.ciiva.com/16155/ksc-11mar10; Contact Material: Silver; Contact Plating: Silver; Depth: 6.8mm; LCSC Part Number: C221766; Lead Free: Lead Free; Length: 6.2mm; Manufacturer Part Number: KSC741JLFS; Max Current Rating: 50mA; Max Operating Temperature: 85°C; Min Operating Temperature: -40°C; Mount: Surface Mount; Orientation: Straight; Package Quantity: 1000; Packaging: Tape and Reel; Part Name: SW-0001; Pricing 1: 1=0.59000, 10=0.56600, 25=0.54520, 100=0.4719; Radiation Hardening: No; REACH SVHC: No SVHC; RoHS: Yes; RoHS Compliant: Yes; Series: KSC; Size: 6.2mm; Stock 1: 157978; Supplier 1: Digi-Key; Supplier Part Number 1: CKN10309CT-ND; Termination: J-Bend; Throw Configuration: SPST; Voltage Rating (DC): 32V; Library Name: Leo-Home-SQL-Lib.DbLib
SW2 = SW-0002: Switch Tactile N.O. SPST Round Button J-Bend; Manufacturer: ITT C&K; Actuator Length: 2.6mm; Actuator Material: Nylon,Polyamide,PA66; Actuator Type: Standard; Contact Current Rating: 50mA; Database Table Name: Switches; Illumination Type: Non-Illuminated,Illuminated; Max Voltage Rating (DC): 32V; Mechanical Life: 300000Cycles; Operating Force: 5N; Power Rating: 1W; Switch Function: Off-Mom; Switch Travel: 0.75mm; Case/Package: SMD/SMT; Category: Tactile Switches; Color: Black; Component Kind: Standard; ComponentLink1Description: https://datasheet.ciiva.com/29903/getdatashee; ComponentLink1URL: https://datasheet.ciiva.com/29903/getdatashee; ComponentLink2Description: https://datasheet.ciiva.com/14920/pts645-1492; ComponentLink2URL: https://datasheet.ciiva.com/14920/pts645-1492; ComponentLink3Description: https://datasheet.ciiva.com/22637/1707731-226; ComponentLink3URL: https://datasheet.ciiva.com/22637/1707731-226; Contact Material: Silver; Contact Plating: Silver; Current Rating: 50mA; Depth: 6.8mm; LCSC Part Number: C221748; Lead Free: Lead Free; Length: 6.2mm; Manufacturer Part Number: KSC441J70SHLFS; Max Current Rating: 50mA; Max Operating Temperature: 85°C; Min Operating Temperature: -40°C; Mount: Surface Mount; Orientation: Straight; Package Quantity: 1; Packaging: Tape and Reel; Part Name: SW-0002; Pricing 1: 1=0.61000, 10=0.58400, 25=0.56200, 100=0.4863; REACH SVHC: No SVHC; RoHS: Yes; Series: KSC; Stock 1: 60296; Supplier 1: Digi-Key; Supplier Part Number 1: 401-1784-1-ND; Termination: J-Bend; Throw Configuration: SPST; Voltage Rating (DC): 32V; Library Name: Leo-Home-SQL-Lib.DbLib
U1 = dd-0001: IC TXRX RS485 HALF DUPLEX 8SOIC; BOM_Manufacturer: EXAR; Data Rate: 10Mbps; Database Table Name: Digital-Drivers; Interface: RS-422,RS-485; Logic Function: Transceiver; Max Power Dissipation: 550mW; Max Supply Voltage: 5.25V; Min Supply Voltage: 4.75V; Nominal Supply Current: 900uA; Number of Drivers: 1; Operating Supply Voltage: 5V; Package Display: No; BOM_JLCPCB Part Class: Basic Part; BOM_Manufacturer Part: SP485EEN-L/TR; BOM_Supplier: LCSC; BOM_Supplier Part: C6855; Case/Package: SOIC; Category: Interface ICs; Component Kind: Standard; ComponentLink1Description: https://datasheet.ciiva.com/16991/0900766b80d; ComponentLink1URL: https://datasheet.ciiva.com/16991/0900766b80d; ComponentLink2Description: https://datasheet.ciiva.com/6633/sp481e-485e-; ComponentLink2URL: https://datasheet.ciiva.com/6633/sp481e-485e-; ComponentLink3Description: https://datasheet.ciiva.com/6633/sp481e-485e-; ComponentLink3URL: https://datasheet.ciiva.com/6633/sp481e-485e-; ComponentLink4Description: https://datasheet.ciiva.com/6633/sp481e-485e-; ComponentLink4URL: https://datasheet.ciiva.com/6633/sp481e-485e-; ComponentLink5Description: https://datasheet.ciiva.com/16378/sp485een-l-; ComponentLink5URL: https://datasheet.ciiva.com/16378/sp485een-l-; Height: 1.65mm; LCSC Part Number: C6855; Lead Free: Lead Free; Length: 4.9mm; Manufacturer: Exar; Manufacturer Part Number: SP485EEN-L/TR; Max Operating Temperature: 85°C; Min Operating Temperature: -40°C; Mount: Surface Mount; Number of Pins: 8; Number of Receivers: 1; Number of Transceivers: 1; Number of Transmitters: 1; Package Quantity: 2500; Packaging: Tape and Reel; Part Name: dd-0001; Radiation Hardening: No; RoHS: Yes; RoHS Compliant: Yes; Simplex/Duplex: Half Duplex; spicePre: U; spiceSymbolName: SP485EEN-L/TR; Supplier 1: Digi-Key; Supplier Part Number 1: SP485EEN-L/TR-ND; Width: 3.9mm; Library Name: Leo-Home-SQL-Lib.DbLib
U2 = Mod-0004: Teensy 4.1 Development Board; Manufacturer: SparkFun; Manufacturer Part Number: DEV-16771; Database Table Name: Modules; Category: Embedded Processors and Controllers; Component Kind: Standard; Part Name: Mod-0004; RoHS: No; Supplier 1: Digi-Key; Supplier Part Number 1: 1568-DEV-16771-ND; Library Name: Leo-Home-SQL-Lib.DbLib
